# Altium schematic sheet M100Z-M3&4_DEVICE.SchDoc
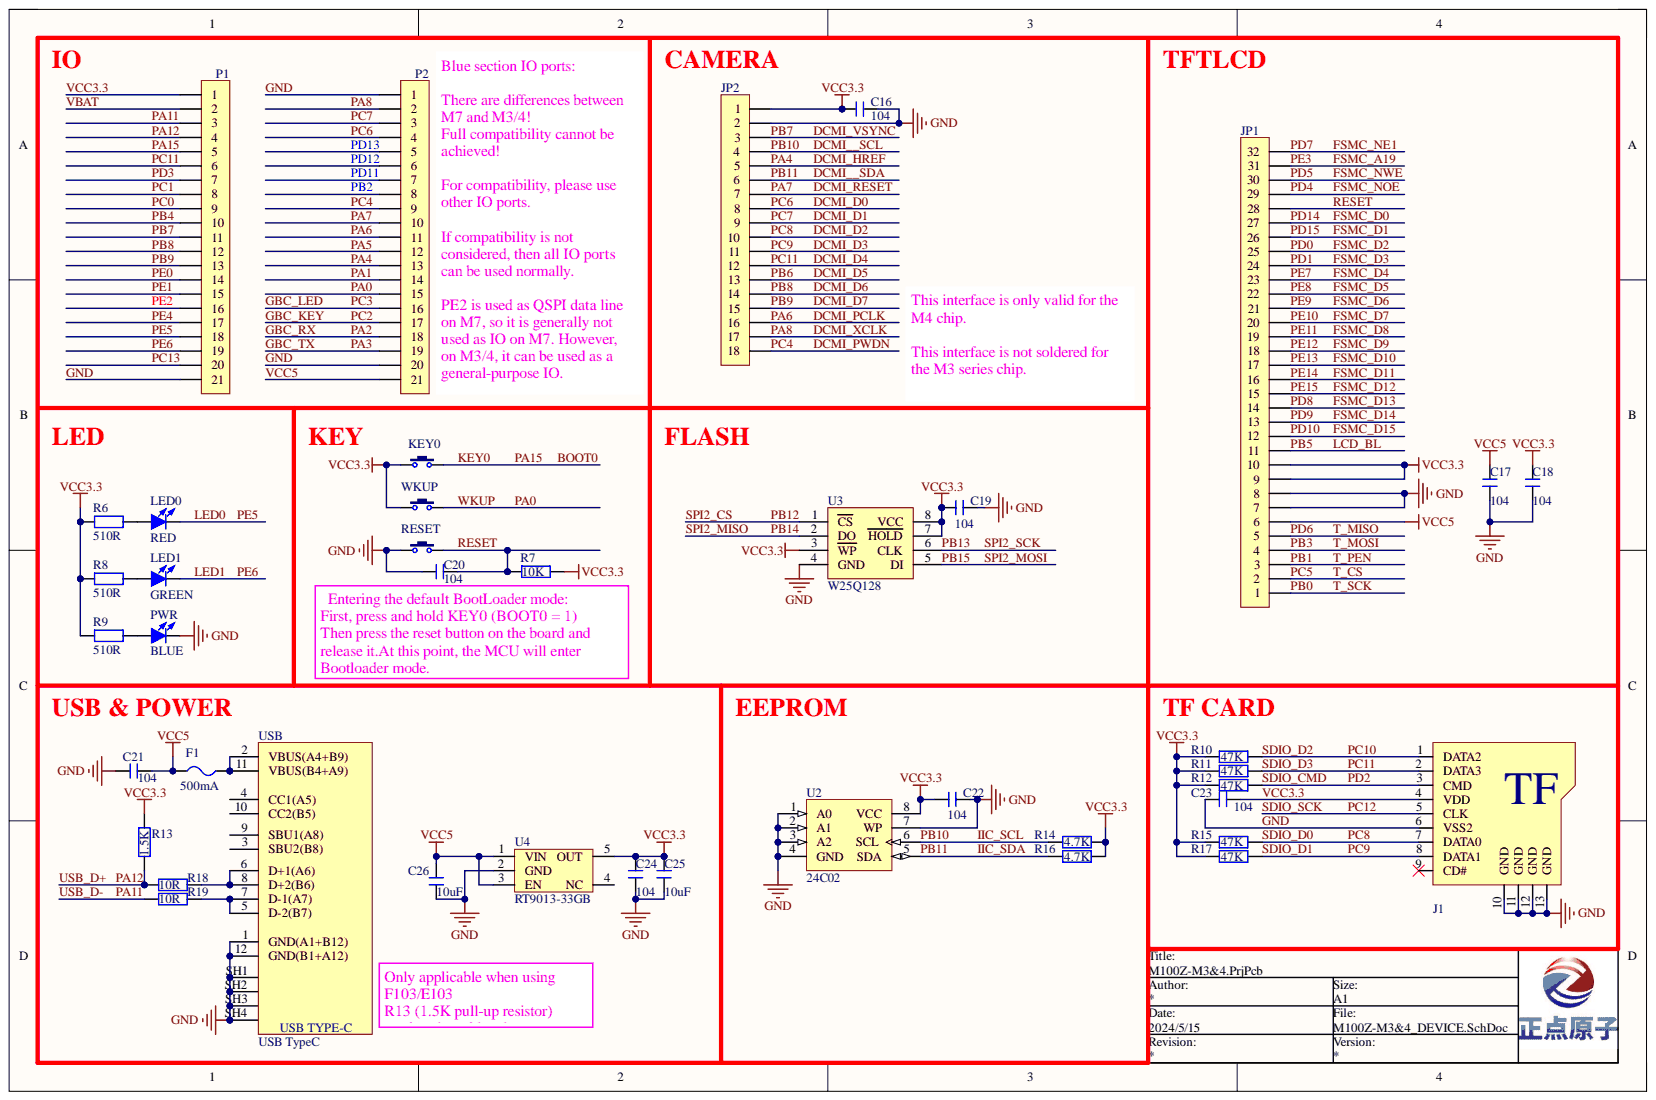
[diagram p0: M100Z-M3&4_DEVICE.SchDoc]

## Parts
C16  104  [C 0402]
C17  104  [C 0402]
C18  104  [C 0402]
C19  104  [C 0402]
C20  104  [C 0402]
C21  104  [C 0402]
C22  104  [C 0402]
C23  104  [C 0402]
C24  104  [C 0402]
C25  10uF  [C 0805]
C26  10uF  [C 0603]
F1  500mA  [FU 0603]
J1  SD-MICRO-TF  [SD-MICRO-TF]  (value not drawn)
JP1  FPC0.5-32P  [FPC 0.5 32P H-S]  (value not drawn)
JP2  FPC0.5-18P  [FPC 0.5 18P H-S]  (value not drawn)
KEY0  KEY0  [KEY 3.2*4.2*2.5 4P SMD]
LED0  RED  [LED 0603 Red]
LED1  GREEN  [LED 0603 Green]
P1  HDR-1X21  [Header 2.54 1X21P]  (value not drawn)
P2  HDR-1X21  [Header 2.54 1X21P]  (value not drawn)
PWR  BLUE  [LED 0603 Blue]
R6  510R  [R 0402]
R7  10K  [R 0402]
R8  510R  [R 0402]
R9  510R  [R 0402]
R10  47K  [R 0402]
R11  47K  [R 0402]
R12  47K  [R 0402]
R13  1.5K  [R 0402]
R14  4.7K  [R 0402]
R15  47K  [R 0402]
R16  4.7K  [R 0402]
R17  47K  [R 0402]
R18  10R  [R 0402]
R19  10R  [R 0402]
RESET  RST  [KEY 3.2*4.2*2.5 4P SMD]  (value not drawn)
U2  24C02  [SOP8_L]
U3  W25Q128  [SOP8W_L]
U4  RT9013-33GB  [SOT23-5N]
USB  USB TypeC  [USB2.0 Type-C]
WKUP  WKUP  [KEY 3.2*4.2*2.5 4P SMD]

## Nets
BOOT0: KEY0.2 P1.5
DCMI__SCL: JP2.4 R14.1 U2.6(SCL)
DCMI__SDA: JP2.6 R16.1 U2.5(SDA)
DCMI_D0: JP2.8 P2.4
DCMI_D1: JP2.9 P2.3
DCMI_D2: J1.7(DATA0) JP2.10 R15.2
DCMI_D3: J1.8(DATA1) JP2.11 R17.2
DCMI_D4: J1.2(DATA3) JP2.12 P1.6 R11.2
DCMI_D5: JP2.13
DCMI_D6: JP2.14 P1.12
DCMI_D7: JP2.15 P1.13
DCMI_HREF: JP2.5 P2.13
DCMI_PCLK: JP2.16 P2.11
DCMI_PWDN: JP2.18 P2.9
DCMI_RESET: JP2.7 P2.10
DCMI_VSYNC: JP2.3 P1.11
DCMI_XCLK: JP2.17 P2.2
FSMC_A19: JP1.31
FSMC_D0: JP1.27
FSMC_D1: JP1.26
FSMC_D2: JP1.25
FSMC_D3: JP1.24
FSMC_D4: JP1.23
FSMC_D5: JP1.22
FSMC_D6: JP1.21
FSMC_D7: JP1.20
FSMC_D8: JP1.19
FSMC_D9: JP1.18
FSMC_D10: JP1.17
FSMC_D11: JP1.16
FSMC_D12: JP1.15
FSMC_D13: JP1.14
FSMC_D14: JP1.13
FSMC_D15: JP1.12
FSMC_NE1: JP1.32
FSMC_NOE: JP1.29
FSMC_NWE: JP1.30
GBC_KEY: P2.17
GBC_LED: P2.16
GBC_RX: P2.18
GBC_TX: P2.19
GND: C16.1 C17.1 C18.1 C19.1 C20.2 C21.2 C22.1 C23.2 C24.1 C25.1 C26.1 J1.6(VSS2) J1.10(GND) J1.11(GND) J1.12(GND) J1.13(GND) JP1.7 JP1.8 JP2.2 P1.21 P2.1 P2.20 PWR.2 RESET.1 U2.1(A0) U2.2(A1) U2.3(A2) U2.4(GND) U2.7(WP) U3.4(GND) U4.2(GND) USB.1(GND(A1+B12)) USB.12(GND(B1+A12)) USB.SH1(Shield1) USB.SH2(Shield2) USB.SH3(Shield3) USB.SH4(Shield4)
LCD_BL: JP1.11
LED0: LED0.2 P1.18
LED1: LED1.2 P1.19
PA0: P2.15 WKUP.2
PA1: P2.14
PA5: P2.12
PA11: P1.3 R19.1
PA12: P1.4 R13.1 R18.1
PB0: JP1.1
PB1: JP1.3
PB2: P2.8
PB3: JP1.4
PB4: P1.10
PB12: U3.1(CS)
PB13: U3.6(CLK)
PB14: U3.2(DO)
PB15: U3.5(DI)
PC0: P1.9
PC1: P1.8
PC5: JP1.2
PC10: J1.1(DATA2) R10.2
PC12: J1.5(CLK)
PC13: P1.20
PD2: J1.3(CMD) R12.2
PD3: P1.7
PD6: JP1.5
PD11: P2.7
PD12: P2.6
PD13: P2.5
PE0: P1.14
PE1: P1.15
PE2: P1.16
PE4: P1.17
RESET: C20.1 JP1.28 R7.2 RESET.2
VBAT: P1.2
VCC3.3: C16.2 C18.2 C19.2 C22.2 C23.1 C24.2 C25.2 J1.4(VDD) JP1.9 JP1.10 JP2.1 KEY0.1 P1.1 R6.1 R7.1 R8.1 R9.1 R10.1 R11.1 R12.1 R13.2 R14.2 R15.1 R16.2 R17.1 U2.8(VCC) U3.3(WP) U3.7(HOLD) U3.8(VCC) U4.5(OUT) WKUP.1
VCC5: C17.2 C21.1 C26.2 F1.1 JP1.6 P2.21 U4.1(VIN) U4.3(EN)
NetF1_2: F1.2 USB.2(VBUS(A4+B9)) USB.11(VBUS(B4+A9))
NetLED0_1: LED0.1 R6.2
NetLED1_1: LED1.1 R8.2
NetPWR_1: PWR.1 R9.2
NetR18_2: R18.2 USB.6(D+1(A6)) USB.8(D+2(B6))
NetR19_2: R19.2 USB.5(D-2(B7)) USB.7(D-1(A7))

Not connected: J1 9(CD#); U4 4(NC); USB 3(SBU2(B8)) 4(CC1(A5)) 9(SBU1(A8)) 10(CC2(B5))

## Library data of the parts
C16-C26 = C: 无极性电容; BOM名称: 无极性贴片电容; 版权归属: 正点原子; Component Kind: Standard; Library Name: 电容.SchLib; 学习资源: www.openedv.com
F1 = F-1000mA: 自恢复保险丝; Component Kind: Standard; Library Name: 保险丝.SchLib
J1 = SD-MICRO-TF: SD,MICRO,TF; Component Kind: Standard; Library Name: 正点原子封装库V1.0.IntLib
JP1 = FPC-32P: FPC连接器; 版权归属: 源创客; BOM名称: FPC连接器; Component Kind: Standard; Library Name: 4.15 - FPC连接器.SchLib; 学习资源: www.ooocke.com
JP2 = FPC-18P: FPC连接器; 版权归属: 源创客; BOM名称: FPC连接器; Component Kind: Standard; Library Name: 4.15 - FPC连接器.SchLib; 学习资源: www.ooocke.com
KEY0 RESET WKUP = KEY: 按键; BOM名称: 3x6轻触开关; Parameter: 按键/开关; 版权归属: 正点原子; Component Kind: Standard; Library Name: 按键-开关.SchLib; 学习资源: www.openedv.com
LED0 LED1 PWR = LED: BOM名称: 插件LED; Parameter: 发光二极管; 版权归属: 正点原子; Component Kind: Standard; Description: LED; Library Name: 二极管_LED.SchLib; 学习资源: www.openedv.com
P1 P2 = Header 21: 21P接插件; BOM名称: 20P接插件; Parameter: 接插件; 版权归属: 正点原子; Component Kind: Standard; 学习资源: www.openedv.com; Library Name: 刘军临时封装库_SCH库.SchLib
R6-R9 R13 R18 R19 = R: 贴片电阻; 版权归属: 源创客; BOM名称: 贴片电阻; Component Kind: Standard; Library Name: 3.1   - 电阻-电容-电感.SchLib; 学习资源: www.ooocke.com
R10-R12 R14-R17 = R: 电阻; BOM名称: 贴片电阻; 版权归属: 正点原子; Component Kind: Standard; Library Name: 电阻.SchLib; Parameter: 电阻; 学习资源: www.openedv.com
U2 = 24Cxx: 外置EEPROM; 版权归属: 源创客; BOM名称: 外置EEPROM; Component Kind: Standard; Library Name: 1.1   -IC芯片.SchLib; 学习资源: www.ooocke.com
U3 = W25Qxx: 外置Flash; 版权归属: 源创客; BOM名称: 外置Flash; Component Kind: Standard; Library Name: 1.1   -IC芯片.SchLib; 学习资源: www.ooocke.com
U4 = RT9013: LDO 5.5V 500mA; BOM名称: 稳压芯片; Parameter: 芯片; 版权归属: 正点原子; Component Kind: Standard; Library Name: 芯片_LDO_DCDC电源.SchLib; 学习资源: www.openedv.com
USB = USB 2.0 Type C: 12P TypeC 母座; Parameter: 接口器件; 版权归属: 正点原子; Component Kind: Standard; 学习资源: www.openedv.com; Library Name: 接口座子-不规则器件.SchLib
